# Altium schematic sheet TKT40339_Rhino_VB_20190430.SCH
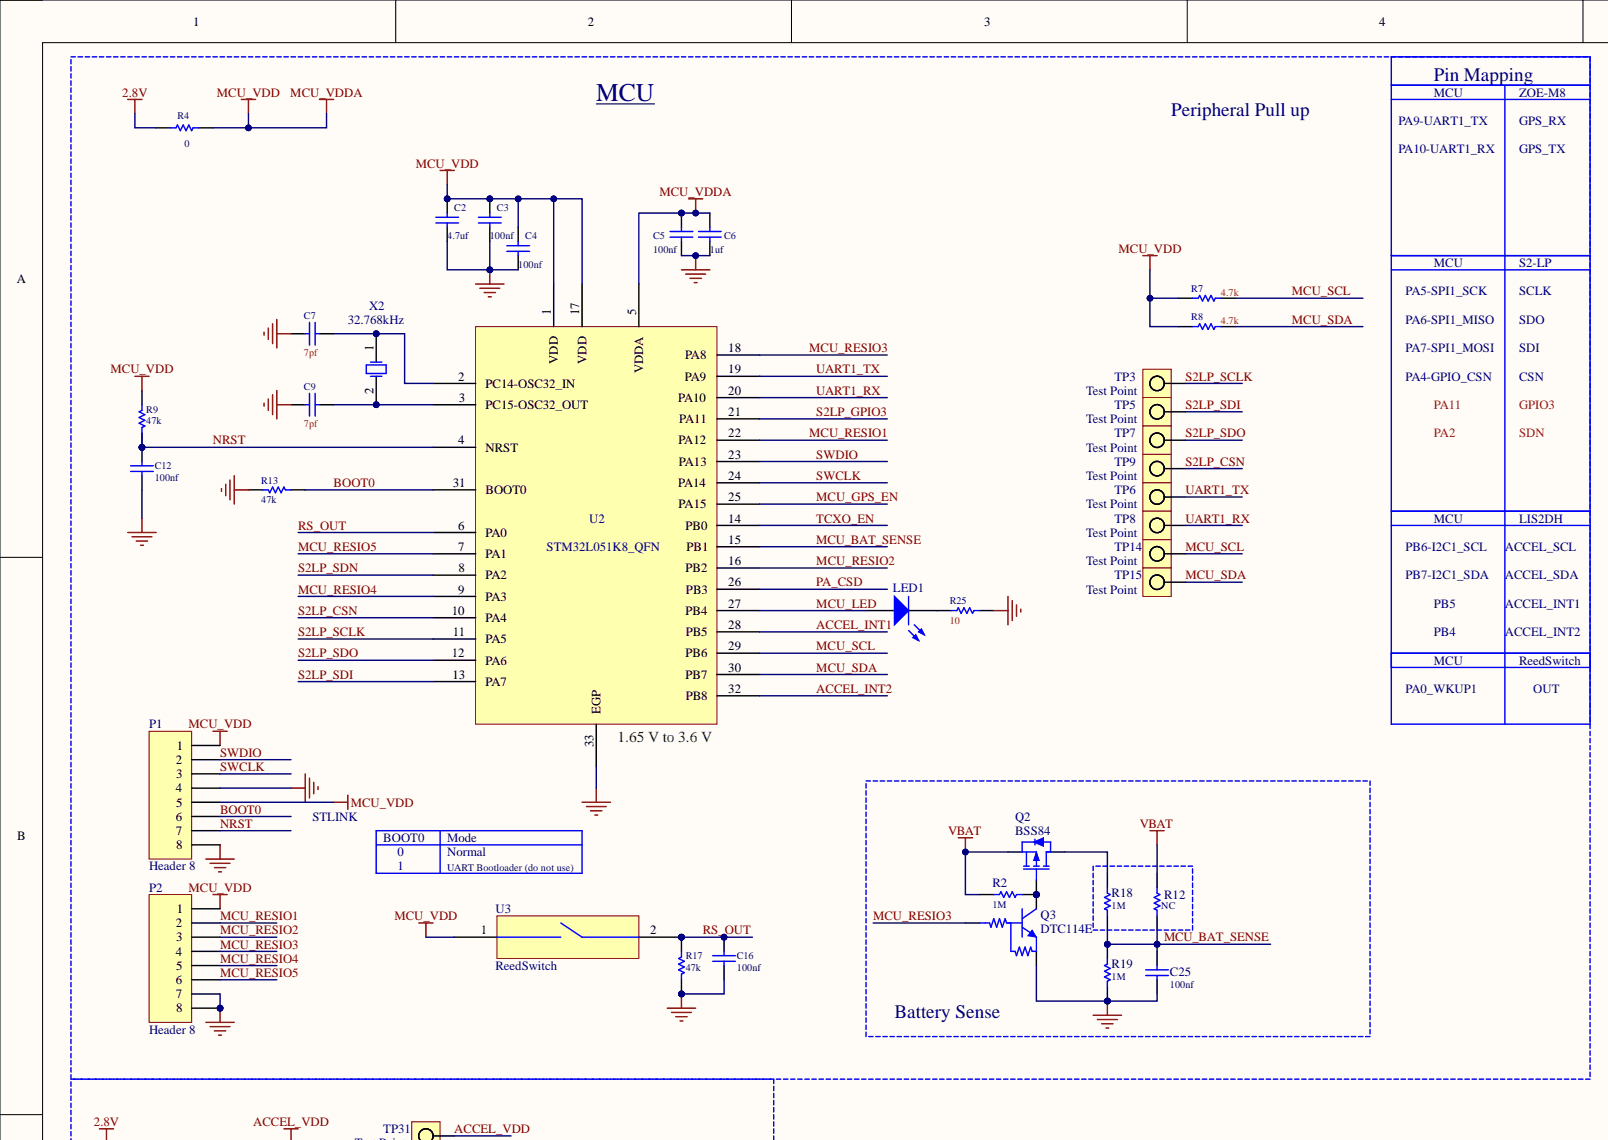
[diagram p0: TKT40339_Rhino_VB_20190430.SCH, top left]
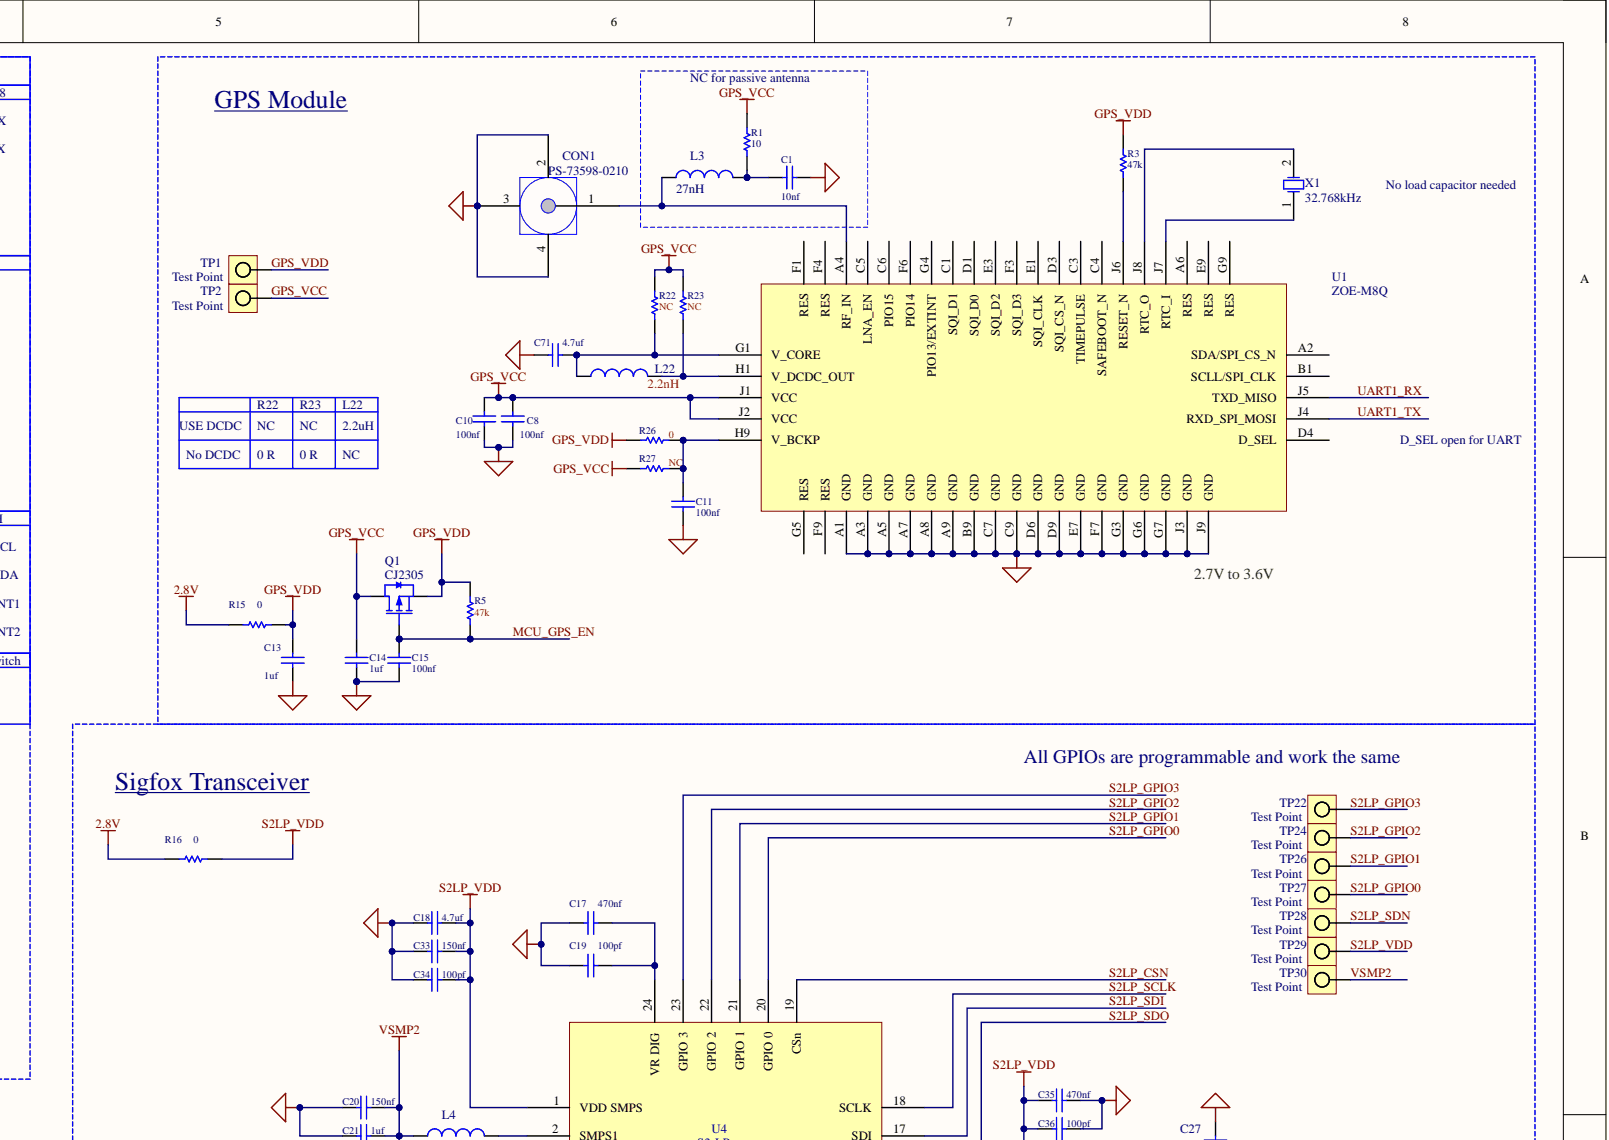
[diagram p1: TKT40339_Rhino_VB_20190430.SCH, top right]
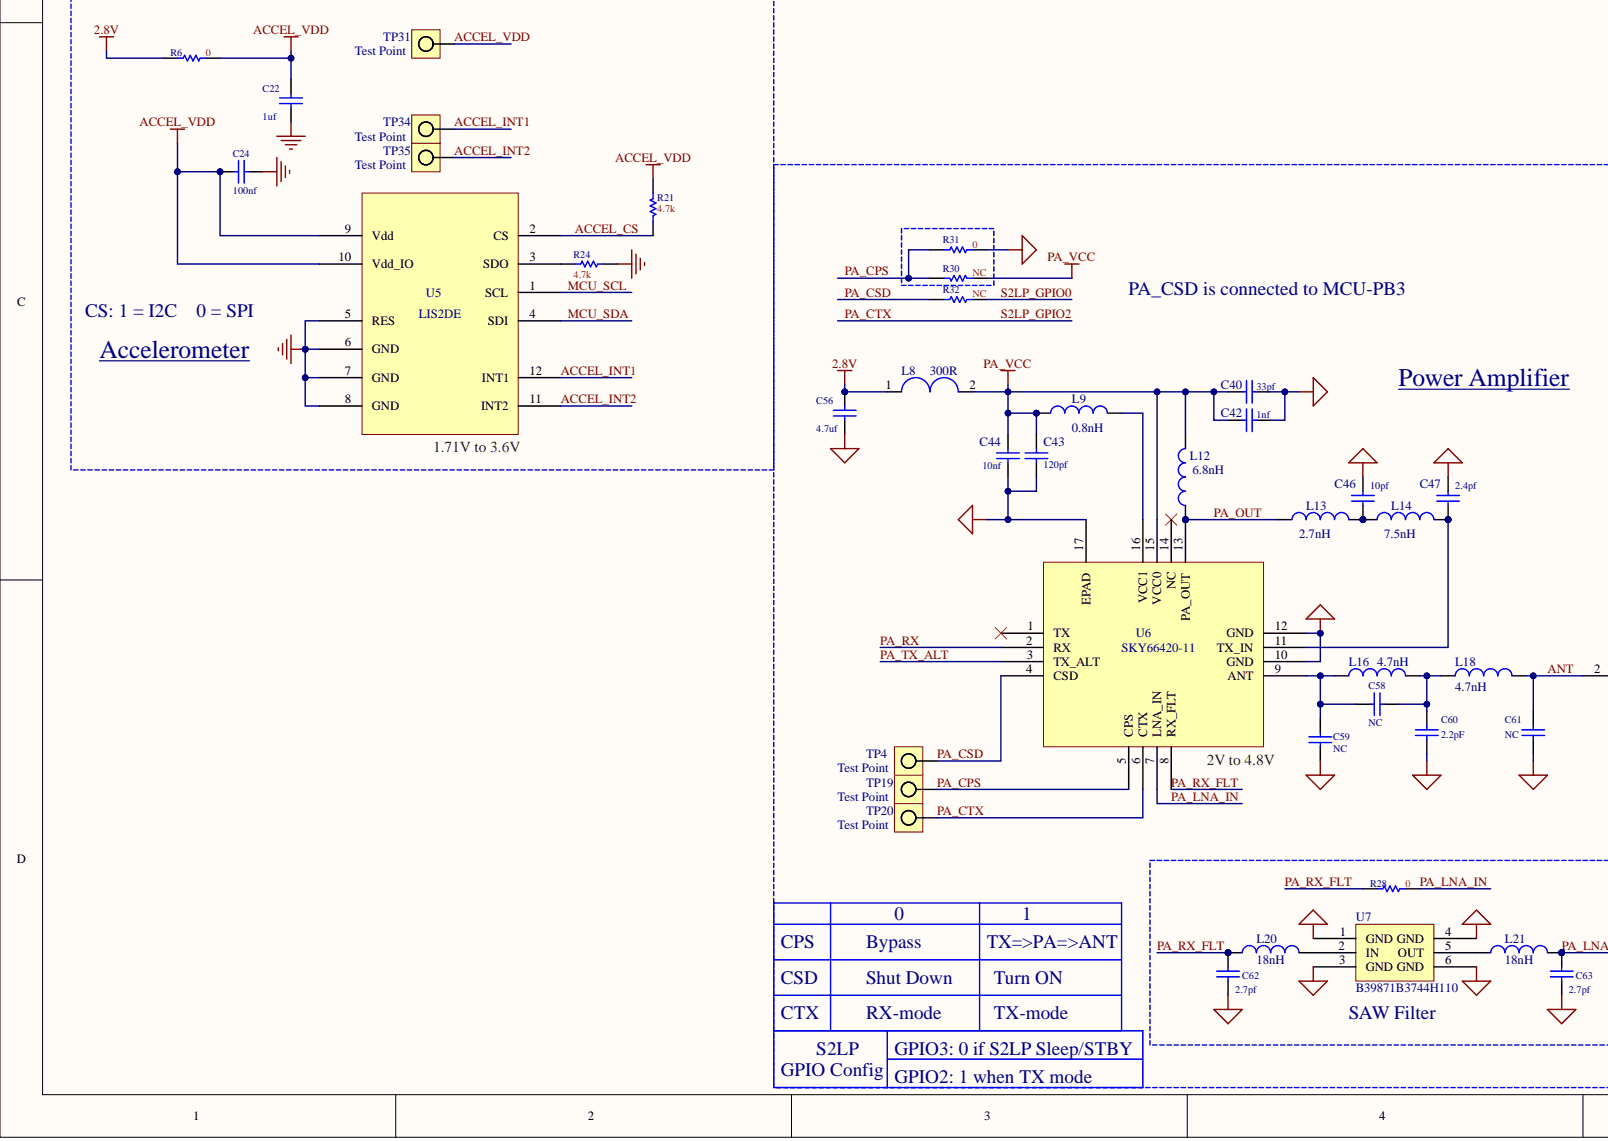
[diagram p2: TKT40339_Rhino_VB_20190430.SCH, bottom left]
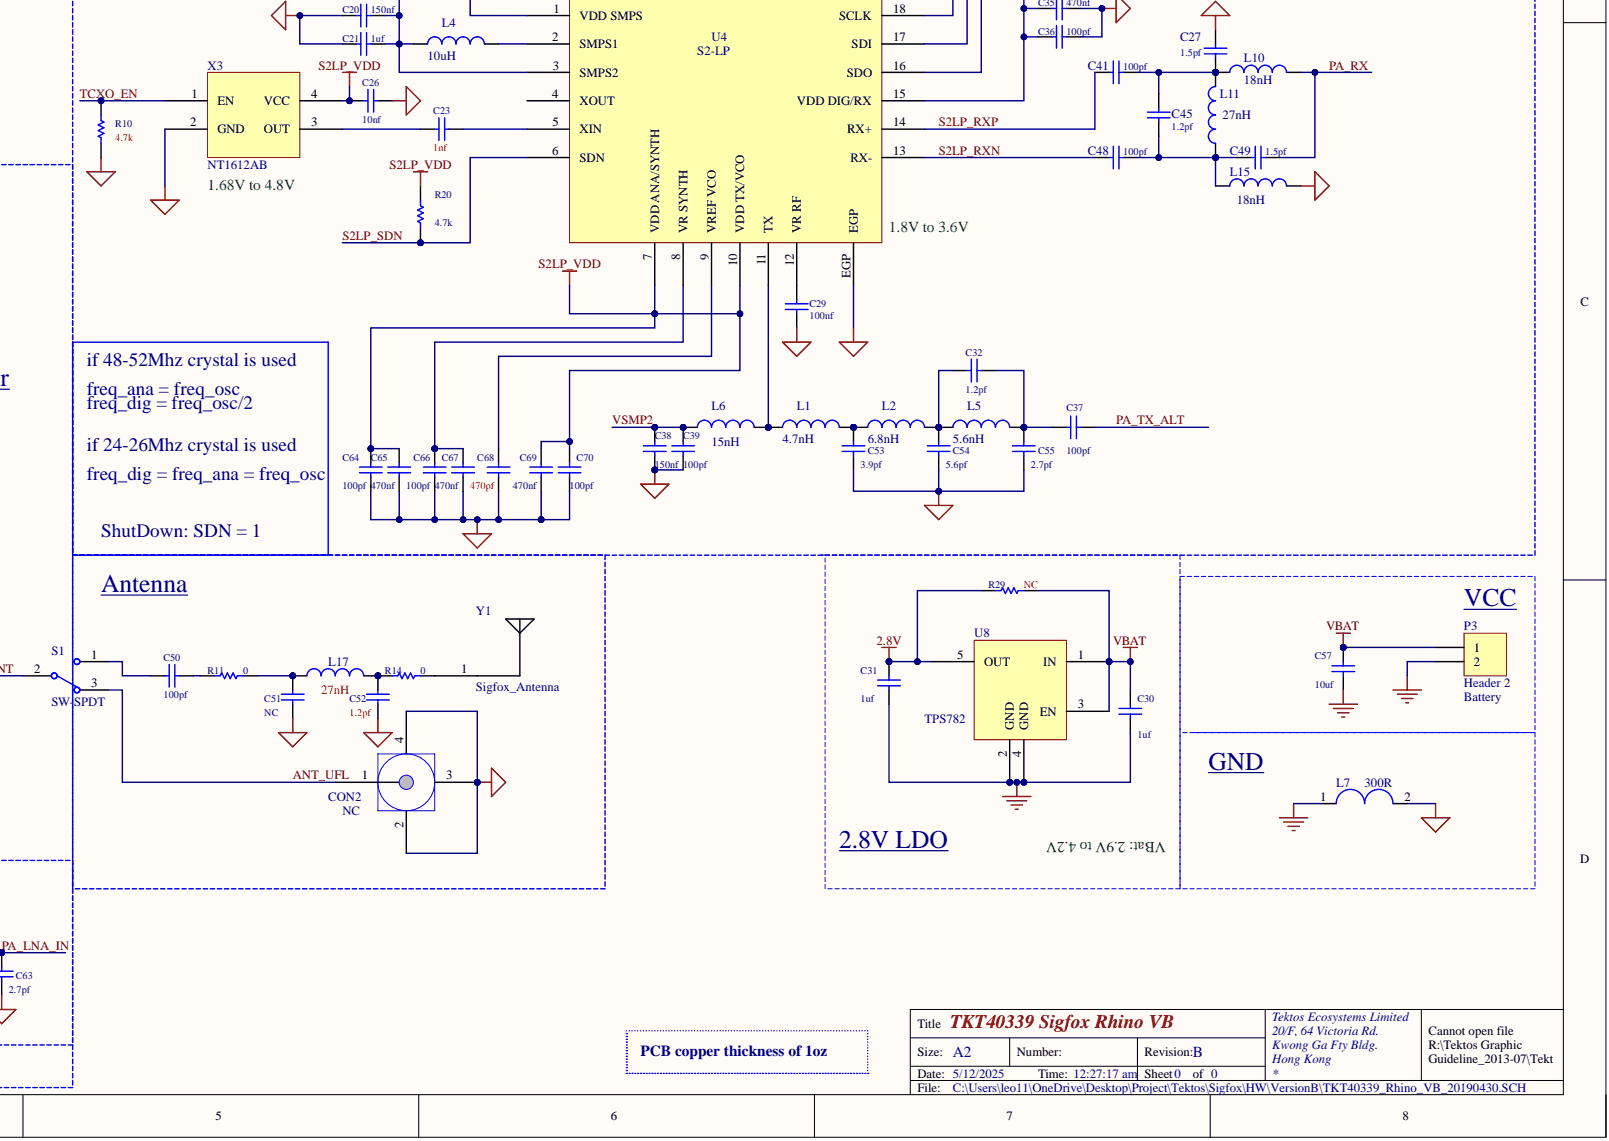
[diagram p3: TKT40339_Rhino_VB_20190430.SCH, bottom right]

## Parts
C1  10nf  [0402C_3D]
C2  4.7uf  [0402C_3D]
C3  100nf  [0402C_3D]
C4  100nf  [0402C_3D]
C5  100nf  [0402C_3D]
C6  1uf  [0402C_3D]
C7  7pf  [0402C_3D]
C8  100nf  [0402C_3D]
C9  7pf  [0402C_3D]
C10  100nf  [0402C_3D]
C11  100nf  [0402C_3D]
C12  100nf  [0402C_3D]
C13  1uf  [0402C_3D]
C14  1uf  [0402C_3D]
C15  100nf  [0402C_3D]
C16  100nf  [0402C_3D]
C17  470nf  [0402C_3D]
C18  4.7uf  [0402C_3D]
C19  100pf  [0402C_3D]
C20  150nf  [0402C_3D]
C21  1uf  [0402C_3D]
C22  1uf  [0402C_3D]
C23  1nf  [0402C_3D]
C24  100nf  [0402C_3D]
C25  100nf  [0402C_3D]
C26  10nf  [0402C_3D]
C27  1.5pf  [0402C_3D]
C29  100nf  [0402C_3D]
C30  1uf  [0402C_3D]
C31  1uf  [0402C_3D]
C32  1.2pf  [0402C_3D]
C33  150nf  [0402C_3D]
C34  100pf  [0402C_3D]
C35  470nf  [0402C_3D]
C36  100pf  [0402C_3D]
C37  100pf  [0402C_3D]
C38  150nf  [0402C_3D]
C39  100pf  [0402C_3D]
C40  33pf  [0402C_3D]
C41  100pf  [0402C_3D]
C42  1nf  [0402C_3D]
C43  120pf  [0402C_3D]
C44  10nf  [0402C_3D]
C45  1.2pf  [0402C_3D]
C46  10pf  [0402C_3D]
C47  2.4pf  [0402C_3D]
C48  100pf  [0402C_3D]
C49  1.5pf  [0402C_3D]
C50  100pf  [0402C_3D]
C51  NC  [0402C_3D]
C52  1.2pf  [0402C_3D]
C53  3.9pf  [0402C_3D]
C54  5.6pf  [0402C_3D]
C55  2.7pf  [0402C_3D]
C56  4.7uf  [0402C_3D]
C57  10uf  [0603C_3D]
C58  NC  [0402C_3D]
C59  NC  [0402C_3D]
C60  2.2pF  [0402C_3D]
C61  NC  [0402C_3D]
C62  2.7pf  [0402C_3D]
C63  2.7pf  [0402C_3D]
C64  100pf  [0402C_3D]
C65  470nf  [0402C_3D]
C66  100pf  [0402C_3D]
C67  470nf  [0402C_3D]
C68  470pf  [0402C_3D]
C69  470nf  [0402C_3D]
C70  100pf  [0402C_3D]
C71  4.7uf  [0402C_3D]
CON1  PS-73598-0210  [UFL_connector]
CON2  NC  [UFL_connector]
L1  4.7nH  [0402L_3D]
L2  6.8nH  [0402L_3D]
L3  27nH  [0402L_3D]
L4  10uH  [0603L_3D]
L5  5.6nH  [0402L_3D]
L6  15nH  [0402L_3D]
L7  300R  [0402L_3D]
L8  300R  [0402L_3D]
L9  0.8nH  [0402L_3D]
L10  18nH  [0402L_3D]
L11  27nH  [0402L_3D]
L12  6.8nH  [0402L_3D]
L13  2.7nH  [0402L_3D]
L14  7.5nH  [0402L_3D]
L15  18nH  [0402L_3D]
L16  4.7nH  [0402L_3D]
L17  27nH  [0402L_3D]
L18  4.7nH  [0402L_3D]
L20  18nH  [0402L_3D]
L21  18nH  [0402L_3D]
L22  2.2nH  [0603]
LED1  LED1  [APHHS1005QBC/D_LED0402_B_3D]
P1  Header 8  [HEADER_8P]
P2  Header 8  [HEADER_8P]
P3  Header 2  [HDR1X2]
Q1  CJ2305  [SOT23_3D (TO-236AB)]
Q2  BSS84  [SOT323_3D]
Q3  DTC114E  [SOT323_3D]
R1  10  [0402R-v2_3D]
R2  1M  [0402R-v2_3D]
R3  47k  [0402R-v2_3D]
R4  0  [0402R-v2_3D]
R5  47k  [0402R-v2_3D]
R6  0  [0402R-v2_3D]
R7  4.7k  [0402R-v2_3D]
R8  4.7k  [0402R-v2_3D]
R9  47k  [0402R-v2_3D]
R10  4.7k  [0402R-v2_3D]
R11  0  [0402R-v2_3D]
R12  NC  [0402R-v2_3D]
R13  47k  [0402R-v2_3D]
R14  0  [0402R-v2_3D]
R15  0  [0402R-v2_3D]
R16  0  [0402R-v2_3D]
R17  47k  [0402R-v2_3D]
R18  1M  [0402R-v2_3D]
R19  1M  [0402R-v2_3D]
R20  4.7k  [0402R-v2_3D]
R21  4.7k  [0402R-v2_3D]
R22  NC  [0402R-v2_3D]
R23  NC  [0402R-v2_3D]
R24  4.7k  [0402R-v2_3D]
R25  10  [0402R-v2_3D]
R26  0  [0402R-v2_3D]
R27  NC  [0402R-v2_3D]
R28  0  [0402R-v2_3D]
R29  NC  [0402R-v2_3D]
R30  NC  [0402R-v2_3D]
R31  0  [0402R-v2_3D]
R32  NC  [0402R-v2_3D]
S1  SW-SPDT  [0402R 3 pad L shape]
TP1  Test Point  [0.8mm Test pin]
TP2  Test Point  [0.8mm Test pin]
TP3  Test Point  [0.8mm Test pin]
TP4  Test Point  [0.8mm Test pin]
TP5  Test Point  [0.8mm Test pin]
TP6  Test Point  [0.8mm Test pin]
TP7  Test Point  [0.8mm Test pin]
TP8  Test Point  [0.8mm Test pin]
TP9  Test Point  [0.8mm Test pin]
TP14  Test Point  [0.8mm Test pin]
TP15  Test Point  [0.8mm Test pin]
TP19  Test Point  [0.8mm Test pin]
TP20  Test Point  [0.8mm Test pin]
TP22  Test Point  [0.8mm Test pin]
TP24  Test Point  [0.8mm Test pin]
TP26  Test Point  [0.8mm Test pin]
TP27  Test Point  [0.8mm Test pin]
TP28  Test Point  [0.8mm Test pin]
TP29  Test Point  [0.8mm Test pin]
TP30  Test Point  [0.8mm Test pin]
TP31  Test Point  [0.8mm Test pin]
TP34  Test Point  [0.8mm Test pin]
TP35  Test Point  [0.8mm Test pin]
U1  ZOE-M8Q  [s-lga]
U2  STM32L051K8_QFN  [UFQFPN32]
U3  ReedSwitch  [Reed switch 14027-Lifted]
U4  S2-LP  [QFN24L_4x4mm]
U5  LIS2DE  [LGA12-1]
U6  SKY66420-11  [SKY66420-11]
U7  B39871B3744H110  [3.0x3.0x1.0mm sawfilter]
U8  TPS782  [TSOT23-5]
X1  32.768kHz  [1.6x1.0x0.5mm_crystal]
X2  32.768kHz  [1.6x1.0x0.5mm_crystal]
X3  NT1612AB  [1.6x1.2x0.45mm tcxo]
Y1  Sigfox_Antenna  [Sigfox_Antenna]

## Nets
2.8V: C31.2 C56.2 L8.1 R4.2 R6.2 R15.2 R16.2 R29.2 U8.5(OUT)
AGND: C1.2 C8.2 C10.2 C11.1 C13.1 C14.1 C15.1 C17.2 C18.2 C19.2 C20.1 C21.1 C26.2 C27.2 C29.2 C33.1 C34.2 C35.1 C36.1 C38.1 C39.1 C40.2 C42.2 C43.2 C44.2 C46.2 C47.2 C51.1 C52.1 C53.1 C54.1 C55.1 C56.1 C59.1 C60.1 C61.1 C62.1 C63.1 C64.1 C65.1 C66.1 C67.1 C68.1 C69.1 C70.1 C71.1 CON1.2 CON1.3 CON1.4 CON2.2 CON2.3 CON2.4 L7.2 L15.2 R10.1 R31.1 U1.A1(GND) U1.A3(GND) U1.A5(GND) U1.A7(GND) U1.A8(GND) U1.A9(GND) U1.B9(GND) U1.C7(GND) U1.C9(GND) U1.D6(GND) U1.D9(GND) U1.E7(GND) U1.F7(GND) U1.G3(GND) U1.G6(GND) U1.G7(GND) U1.J3(GND) U1.J9(GND) U4.EGP U6.10(GND) U6.12(GND) U6.17(EPAD) U7.1(GND) U7.3(GND) U7.4(GND) U7.6(GND) X3.2(GND)
GND: C2.1 C3.1 C4.1 C5.1 C6.1 C7.2 C9.2 C12.1 C16.1 C22.1 C24.1 C25.2 C30.1 C31.1 C57.1 L7.1 P1.4 P1.8 P2.7 P2.8 P3.2 Q3.2 R13.1 R17.1 R19.1 R24.2 R25.1 U2.33(EGP) U5.5(RES) U5.6(GND) U5.7(GND) U5.8(GND) U8.2(GND) U8.4(GND)
MCU_VDD: C2.2 C3.2 C4.2 C5.2 C6.2 P1.1 P1.5 P2.1 R4.1 R7.2 R8.2 R9.2 U2.1(VDD) U2.5(VDDA) U2.17(VDD) U3.1
PA_VCC: C40.1 C42.1 C43.1 C44.1 L8.2 L9.1 L12.2 R30.1 U6.15(VCC0)
VBAT: C30.2 C57.2 P3.1 Q2.2 R2.2 R12.1 R29.1 U8.1(IN) U8.3(EN)
ACCEL_CS: R21.1 U5.2(CS)
ACCEL_INT1: TP34.1 U2.28(PB5) U5.12(INT1)
ACCEL_INT2: TP35.1 U2.32(PB8) U5.11(INT2)
ACCEL_VDD: C22.2 C24.2 R6.1 R21.2 TP31.1 U5.9(Vdd) U5.10(Vdd_IO)
ANT: C61.2 L18.2 S1.2
ANT_UFL: CON2.1 S1.3
BOOT0: P1.6 R13.2 U2.31(BOOT0)
GPS_VCC: C8.1 C10.1 C14.2 Q1.3 R1.1 R22.1 R23.1 R27.1 TP2.1 U1.J1(VCC) U1.J2(VCC)
GPS_VDD: C13.2 Q1.2 R3.1 R5.2 R15.1 R26.1 TP1.1
MCU_BAT_SENSE: C25.1 R12.2 R18.1 R19.2 U2.15(PB1)
MCU_GPS_EN: C15.2 Q1.1 R5.1 U2.25(PA15)
MCU_LED: LED1.1 U2.27(PB4)
MCU_RESIO1: P2.2 U2.22(PA12)
MCU_RESIO2: P2.3 U2.16(PB2)
MCU_RESIO3: P2.4 Q3.1 U2.18(PA8)
MCU_RESIO4: P2.5 U2.9(PA3)
MCU_RESIO5: P2.6 U2.7(PA1)
MCU_SCL: R7.1 TP14.1 U2.29(PB6) U5.1(SCL)
MCU_SDA: R8.1 TP15.1 U2.30(PB7) U5.4(SDI)
NRST: C12.2 P1.7 R9.1 U2.4(NRST)
PA_CPS: R30.2 R31.2 TP19.1 U6.5(CPS)
PA_CSD: R32.2 TP4.1 U2.26(PB3) U6.4(CSD)
PA_CTX: TP20.1 TP24.1 U4.22(GPIO_2) U6.6(CTX)
PA_LNA_IN: C63.2 L21.2 R28.1 U6.7(LNA_IN)
PA_OUT: L12.1 L13.1 U6.13(PA_OUT)
PA_RX: C49.1 L10.2 U6.2(RX)
PA_RX_FLT: C62.2 L20.1 R28.2 U6.8(RX_FLT)
PA_TX_ALT: C37.2 U6.3(TX_ALT)
RS_OUT: C16.2 R17.2 U2.6(PA0) U3.2
S2LP_CSN: TP9.1 U2.10(PA4) U4.19(CSn)
S2LP_GPIO0: R32.1 TP27.1 U4.20(GPIO_0)
S2LP_GPIO1: TP26.1 U4.21(GPIO_1)
S2LP_GPIO3: TP22.1 U2.21(PA11) U4.23(GPIO_3)
S2LP_RXN: C48.1 U4.13(RX-)
S2LP_RXP: C41.1 U4.14(RX+)
S2LP_SCLK: TP3.1 U2.11(PA5) U4.18(SCLK)
S2LP_SDI: TP5.1 U2.13(PA7) U4.17(SDI)
S2LP_SDN: R20.1 TP28.1 U2.8(PA2) U4.6(SDN)
S2LP_SDO: TP7.1 U2.12(PA6) U4.16(SDO)
S2LP_VDD: C18.1 C26.1 C33.2 C34.1 C35.2 C36.2 C64.2 C65.2 C69.2 C70.2 R16.1 R20.2 TP29.1 U4.1(VDD_SMPS) U4.7(VDD_ANA/SYNTH) U4.10(VDD_TX/VCO) U4.15(VDD_DIG/RX) X3.4(VCC)
SWCLK: P1.3 U2.24(PA14)
SWDIO: P1.2 U2.23(PA13)
TCXO_EN: R10.2 U2.14(PB0) X3.1(EN)
UART1_RX: TP8.1 U1.J5(TXD_MISO) U2.20(PA10)
UART1_TX: TP6.1 U1.J4(RXD_SPI_MOSI) U2.19(PA9)
VSMP2: C20.2 C21.2 C38.2 C39.2 L4.1 L6.1 TP30.1 U4.3(SMPS2)
NetC1_1: C1.1 L3.2 R1.2
NetC7_1: C7.1 U2.2(PC14-OSC32_IN) X2.1
NetC9_1: C9.1 U2.3(PC15-OSC32_OUT) X2.2
NetC11_2: C11.2 R26.2 R27.2 U1.H9(V_BCKP)
NetC17_1: C17.1 C19.1 U4.24(VR_DIG)
NetC23_1: C23.1 U4.5(XIN)
NetC23_2: C23.2 X3.3(OUT)
NetC27_1: C27.1 C41.2 C45.2 L10.1 L11.2
NetC29_1: C29.1 U4.12(VR_RF)
NetC32_1: C32.1 C37.1 C55.2 L5.2
NetC32_2: C32.2 C54.2 L2.2 L5.1
NetC45_1: C45.1 C48.2 C49.2 L11.1 L15.1
NetC46_1: C46.1 L13.2 L14.1
NetC47_1: C47.1 L14.2 U6.11(TX_IN)
NetC50_1: C50.1 S1.1
NetC50_2: C50.2 R11.2
NetC51_2: C51.2 L17.1 R11.1
NetC52_2: C52.2 L17.2 R14.2
NetC53_2: C53.2 L1.2 L2.1
NetC58_1: C58.1 C59.2 L16.1 U6.9(ANT)
NetC58_2: C58.2 C60.2 L16.2 L18.1
NetC66_2: C66.2 C67.2 U4.8(VR_SYNTH)
NetC68_2: C68.2 U4.9(VREF_VCO)
NetC71_2: C71.2 L22.1 R22.2 U1.G1(V_CORE)
NetCON1_1: CON1.1 L3.1 U1.A4(RF_IN)
NetL1_1: L1.1 L6.2 U4.11(TX)
NetL4_2: L4.2 U4.2(SMPS1)
NetL9_2: L9.2 U6.16(VCC1)
NetL20_2: L20.2 U7.2(IN)
NetL21_1: L21.1 U7.5(OUT)
NetL22_2: L22.2 R23.2 U1.H1(V_DCDC_OUT)
NetLED1_2: LED1.2 R25.2
NetQ2_1: Q2.1 Q3.3 R2.1
NetQ2_3: Q2.3 R18.2
NetR3_2: R3.2 U1.J6(RESET_N)
NetR14_1: R14.1 Y1.1
NetR24_1: R24.1 U5.3(SDO)
NetU1_J7: U1.J7(RTC_I) X1.1
NetU1_J8: U1.J8(RTC_O) X1.2

Not connected: U1 A2(SDA/SPI_CS_N) A6(RES) B1(SCLL/SPI_CLK) C1(SQI_D1) C3(TIMEPULSE) C4(SAFEBOOT_N) C5(LNA_EN) C6(PIO15) D1(SQI_D0) D3(SQI_CS_N) D4(D_SEL) E1(SQI_CLK) E3(SQI_D2) E9(RES) F1(RES) F3(SQI_D3) F4(RES) F6(PIO14) F9(RES) G4(PIO13/EXTINT) G5(RES) G9(RES); U4 4(XOUT); U6 1(TX) 14(NC)

## Library data of the parts
C1 C26 C44 = Cap: CAP, 0402, 10V, 10nf; Manufacturer: Generic Components; Manufacturer Part Number: 0402ZC103MAT2A; Class I: Passive; Class II: Capacitor; Component Kind: Standard; PN: 0402ZC103MAT2A; Published: 8-Jun-2000; Revision: July-2002: Re-released for DXP Platform.; Publisher: Altium Limited
C2 C18 C56 = Cap: CAP, 0402, 10V, 4.7uf; Manufacturer: Generic Components; Manufacturer Part Number: ZRB15XR61A475ME01D; Class I: Passive; Class II: Capacitor; Component Kind: Standard; PN: ZRB15XR61A475ME01D; Published: 8-Jun-2000; Revision: July-2002: Re-released for DXP Platform.; Publisher: Altium Limited
C3-C5 C8 C10-C12 C15 C16 C24 C25 C29 C71 = Cap: CAP, 0402, 10V, 100nf; Manufacturer: Generic Components; Manufacturer Part Number: 0402ZD104KAT4A; Class I: Passive; Class II: Capacitor; Component Kind: Standard; PN: 0402ZD104KAT4A; Published: 8-Jun-2000; Revision: July-2002: Re-released for DXP Platform.; Publisher: Altium Limited
C6 C13 C14 C21 C22 C30 C31 = Cap: CAP, 0402, 10V, 1uf; Manufacturer: Generic Components; Manufacturer Part Number: CC0402JRX5R6BB104; Class I: Passive; Class II: Capacitor; Component Kind: Standard; PN: CC0402JRX5R6BB104; Published: 8-Jun-2000; Revision: July-2002: Re-released for DXP Platform.; Publisher: Altium Limited
C7 C9 = Cap: CAP, 0402, 10V, 20pf; Manufacturer: Generic Components; Manufacturer Part Number: C0402C200K8GACTU; Class I: Passive; Class II: Capacitor; Component Kind: Standard; PN: C0402C200K8GACTU; Published: 8-Jun-2000; Revision: July-2002: Re-released for DXP Platform.; Publisher: Altium Limited
C17 C35 C65 C67 C69 = Cap: CAP, 0402, 10V, 470nf; Manufacturer: Generic Components; Manufacturer Part Number: LWK105BJ474MP-F; Class I: Passive; Class II: Capacitor; Component Kind: Standard; PN: LWK105BJ474MP-F; Published: 8-Jun-2000; Revision: July-2002: Re-released for DXP Platform.; Publisher: Altium Limited
C19 C34 C36 C37 C39 C41 C48 C50 C64 C66 C70 = Cap: CAP, 0402, 10V, 100pf; Manufacturer: Generic Components; Manufacturer Part Number: 885012205001; Class I: Passive; Class II: Capacitor; Component Kind: Standard; PN: 885012205001; Published: 8-Jun-2000; Revision: July-2002: Re-released for DXP Platform.; Publisher: Altium Limited
C20 C33 C38 = Cap: CAP, 0402, 10V, 150nf; Manufacturer: Generic Components; Manufacturer Part Number: C1005X7R1A154M050BB; Class I: Passive; Class II: Capacitor; Component Kind: Standard; PN: C1005X7R1A154M050BB; Published: 8-Jun-2000; Revision: July-2002: Re-released for DXP Platform.; Publisher: Altium Limited
C23 C42 = Cap: CAP, 0402, 10V, 1nf; Manufacturer: Generic Components; Manufacturer Part Number: C0402C102K1GECAUTO; Class I: Passive; Class II: Capacitor; Component Kind: Standard; PN: C0402C102K1GECAUTO; Published: 8-Jun-2000; Revision: July-2002: Re-released for DXP Platform.; Publisher: Altium Limited
C27 C49 = Cap: CAP, 0402, 10V, 1.5pf; Manufacturer: Generic Components; Manufacturer Part Number: GJM1555C1H1R5BB01D; Class I: Passive; Class II: Capacitor; Component Kind: Standard; PN: GJM1555C1H1R5BB01D; Published: 8-Jun-2000; Revision: July-2002: Re-released for DXP Platform.; Publisher: Altium Limited
C32 C45 = Cap: CAP, 0402, 10V, 1.2pf; Manufacturer: Generic Components; Manufacturer Part Number: GJM1555C1H1R2BB01D; Class I: Passive; Class II: Capacitor; Component Kind: Standard; PN: GJM1555C1H1R2BB01D; Published: 8-Jun-2000; Revision: July-2002: Re-released for DXP Platform.; Publisher: Altium Limited
C40 = Cap: CAP, 0402, 10V, 33pf; Manufacturer: Generic Components; Manufacturer Part Number: 885012005010; Class I: Passive; Class II: Capacitor; Component Kind: Standard; PN: 885012005010; Published: 8-Jun-2000; Revision: July-2002: Re-released for DXP Platform.; Publisher: Altium Limited
C43 = Cap: CAP, 0402, 10V, 120pf; Manufacturer: Generic Components; Manufacturer Part Number: C0402C121J5GACTU; Class I: Passive; Class II: Capacitor; Component Kind: Standard; PN: C0402C121J5GACTU; Published: 8-Jun-2000; Revision: July-2002: Re-released for DXP Platform.; Publisher: Altium Limited
C46 = Cap: CAP, 0402, 10V, 10pf; Manufacturer: Generic Components; Manufacturer Part Number: 885012005007; Class I: Passive; Class II: Capacitor; Component Kind: Standard; PN: 885012005007; Published: 8-Jun-2000; Revision: July-2002: Re-released for DXP Platform.; Publisher: Altium Limited
C47 = Cap: CAP, 0402, 10V, 2.4pf; Manufacturer: Generic Components; Manufacturer Part Number: GJM1555C1H2R4WB01D; Class I: Passive; Class II: Capacitor; Component Kind: Standard; PN: GJM1555C1H2R4WB01D; Published: 8-Jun-2000; Revision: July-2002: Re-released for DXP Platform.; Publisher: Altium Limited
C51 = Cap: CAP, 0402, TBD; Manufacturer: Generic Components; Class I: Passive; Class II: Capacitor; Component Kind: Standard; Published: 8-Jun-2000; Revision: July-2002: Re-released for DXP Platform.; Publisher: Altium Limited
C52 = Cap: CAP, 0402, TBD; Manufacturer: Generic Components; Manufacturer Part Number: GJM1555C1H1R2WB01D; Class I: Passive; Class II: Capacitor; Component Kind: Standard; PN: GJM1555C1H1R2WB01D; Published: 8-Jun-2000; Revision: July-2002: Re-released for DXP Platform.; Publisher: Altium Limited
C53 = Cap: CAP, 0402, 10V, 3.9pf; Manufacturer: Generic Components; Manufacturer Part Number: UVK105CG3R9JW-F; Class I: Passive; Class II: Capacitor; Component Kind: Standard; PN: UVK105CG3R9JW-F; Published: 8-Jun-2000; Revision: July-2002: Re-released for DXP Platform.; Publisher: Altium Limited
C54 = Cap: CAP, 0402, 10V, 5.6pf; Manufacturer: Generic Components; Manufacturer Part Number: VJ0402A5R6CXQPW1BC; Class I: Passive; Class II: Capacitor; Component Kind: Standard; PN: VJ0402A5R6CXQPW1BC; Published: 8-Jun-2000; Revision: July-2002: Re-released for DXP Platform.; Publisher: Altium Limited
C55 C62 C63 = Cap: CAP, 0402, 10V, 2.7pf; Manufacturer: Generic Components; Manufacturer Part Number: GRM0225C1E2R7CA03L; Class I: Passive; Class II: Capacitor; Component Kind: Standard; PN: GRM0225C1E2R7CA03L; Published: 8-Jun-2000; Revision: July-2002: Re-released for DXP Platform.; Publisher: Altium Limited
C57 = Cap: CAP, 0603, 16V, 10uf; Manufacturer: Generic Components; Manufacturer Part Number: C0603C103M4RACTU; Class I: Passive; Class II: Capacitor; Component Kind: Standard; PN: C0603C103M4RACTU; Published: 8-Jun-2000; Revision: July-2002: Re-released for DXP Platform.; Publisher: Altium Limited
C58 C59 C61 = Cap: CAP, 0402, NC; Manufacturer: Generic Components; Class I: Passive; Class II: Capacitor; Component Kind: Standard; Published: 8-Jun-2000; Revision: July-2002: Re-released for DXP Platform.; Publisher: Altium Limited
C60 = Cap: CAP, 0402, 10V, 2.2pf; Manufacturer: Generic Components; Manufacturer Part Number: 885012005003; Class I: Passive; Class II: Capacitor; Component Kind: Standard; PN: 885012005003; Published: 8-Jun-2000; Revision: July-2002: Re-released for DXP Platform.; Publisher: Altium Limited
C68 = Cap: CAP, 0402, 10V, 470pf; Manufacturer: Generic Components; Manufacturer Part Number: 885012005017; Class I: Passive; Class II: Capacitor; Component Kind: Standard; PN: 885012005017; Published: 8-Jun-2000; Revision: July-2002: Re-released for DXP Platform.; Publisher: Altium Limited
CON1 = UFL_RF_CONNECTOR: UFL connector; Manufacturer Part Number: CONUFL001-SMD; Component Kind: Standard; PN: CONUFL001-SMD; Library Name: TektosLib.SchLib
CON2 = UFL_RF_CONNECTOR: UFL connector; Component Kind: Standard; Library Name: TektosLib.SchLib
L1 L16 L18 = Inductor: Inductor, 4.7nH, 0402; Manufacturer Part Number: MLG1005S4N7CT000; Component Kind: Standard; Library Name: Miscellaneous Devices.IntLib; PN: MLG1005S4N7CT000; Published: 8-Jun-2000; Signal Integrity: Inductor; Simulation: INDUCTOR; Publisher: Altium Limited
L2 L12 = Inductor: Inductor, 6.8nH, 0402; Manufacturer Part Number: LQG15HZ6N8J02D; Component Kind: Standard; Library Name: Miscellaneous Devices.IntLib; PN: LQG15HZ6N8J02D; Published: 8-Jun-2000; Signal Integrity: Inductor; Simulation: INDUCTOR; Publisher: Altium Limited
L3 L11 = Inductor: Inductor, 27nH, 0402; Manufacturer Part Number: LQW15AN27NG80D; Component Kind: Standard; Library Name: Miscellaneous Devices.IntLib; PN: LQW15AN27NG80D; Published: 8-Jun-2000; Signal Integrity: Inductor; Simulation: INDUCTOR; Publisher: Altium Limited
L4 = Inductor: Inductor, 10uH, 0603; Manufacturer Part Number: LQM18FN100M00D; Component Kind: Standard; Library Name: Miscellaneous Devices.IntLib; PN: LQM18FN100M00D; Published: 8-Jun-2000; Signal Integrity: Inductor; Simulation: INDUCTOR; Publisher: Altium Limited
L5 = Inductor: Inductor, 5.6nH, 0402; Manufacturer Part Number: LQG15WZ5N6S02D; Component Kind: Standard; Library Name: Miscellaneous Devices.IntLib; PN: LQG15WZ5N6S02D; Published: 8-Jun-2000; Signal Integrity: Inductor; Simulation: INDUCTOR; Publisher: Altium Limited
L6 = Inductor: Inductor, 15nH, 0402; Manufacturer Part Number: LQG15HZ15NJ02D; Component Kind: Standard; Library Name: Miscellaneous Devices.IntLib; PN: LQG15HZ15NJ02D; Published: 8-Jun-2000; Signal Integrity: Inductor; Simulation: INDUCTOR; Publisher: Altium Limited
L7 L8 = FERRITE_BEAD: Ferrite bead, 300R, 200mA; Manufacturer Part Number: ILBB0402ER301V; Component Kind: Standard; PN: ILBB0402ER301V; Library Name: TektosLib.SchLib
L9 = Inductor: Inductor, 0.8nH, 0402; Manufacturer Part Number: MLG1005S0N8C; Component Kind: Standard; Library Name: Miscellaneous Devices.IntLib; PN: MLG1005S0N8C; Published: 8-Jun-2000; Signal Integrity: Inductor; Simulation: INDUCTOR; Publisher: Altium Limited
L10 L15 L20 L21 = Inductor: Inductor, 18nH, 0402; Manufacturer Part Number: LQG15HN18NH02D; Component Kind: Standard; Library Name: Miscellaneous Devices.IntLib; PN: LQG15HN18NH02D; Published: 8-Jun-2000; Signal Integrity: Inductor; Simulation: INDUCTOR; Publisher: Altium Limited
L13 = Inductor: Inductor, 2.7nH, 0402; Manufacturer Part Number: GCM1555C1H2R7BA16D; Component Kind: Standard; Library Name: Miscellaneous Devices.IntLib; PN: GCM1555C1H2R7BA16D; Published: 8-Jun-2000; Signal Integrity: Inductor; Simulation: INDUCTOR; Publisher: Altium Limited
L14 = Inductor: Inductor, 7.5nH, 0402; Manufacturer Part Number: LQG15HS7N5H02D; Component Kind: Standard; Library Name: Miscellaneous Devices.IntLib; PN: LQG15HS7N5H02D; Published: 8-Jun-2000; Signal Integrity: Inductor; Simulation: INDUCTOR; Publisher: Altium Limited
L17 = Inductor: Inductor, TBD, 0402; Manufacturer Part Number: LQW15AN27NG80D; Component Kind: Standard; Library Name: Miscellaneous Devices.IntLib; PN: LQW15AN27NG80D; Published: 8-Jun-2000; Signal Integrity: Inductor; Simulation: INDUCTOR; Publisher: Altium Limited
L22 = Inductor: Inductor, 2.2nH, 0402; Manufacturer Part Number: LQM2MPN2R2NG0; Component Kind: Standard; Library Name: Miscellaneous Devices.IntLib; PN: LQM2MPN2R2NG0; Published: 8-Jun-2000; Signal Integrity: Inductor; Simulation: INDUCTOR; Publisher: Altium Limited
LED1 = LED1: LED Green 0402; Manufacturer Part Number: APHHS1005CGCK; Component Kind: Standard; PN: APHHS1005CGCK; Library Name: TektosLib.SchLib
P1 P2 = Header 8: Header, 8-Pin; Component Kind: Standard; Library Name: Miscellaneous Connectors.IntLib; Signal Integrity: Connector; Publisher: Altium Limited
P3 = Header 2: Header, 2-Pin; Component Kind: Standard; Library Name: Miscellaneous Connectors.IntLib; Signal Integrity: Connector; Publisher: Altium Limited
Q1 = CJ2305: P-CHANNEL 8-VDS MOSFET; Component Kind: Standard; Manufacturer Part Number: CJ2305; PN: CJ2305; Library Name: TektosLib.SchLib
Q2 = BSS84: P-CHANNEL ENHANCEMENT MODE MOSFET; Manufacturer Part Number: BSS84WQ-7-F; Component Kind: Standard; PN: BSS84WQ-7-F; Library Name: TektosLib.SchLib
Q3 = DTC114E: R1=10K, R2=10K, NPN Transistors with Monolithic Bias  Resistor NetworkNPN Transistors with Monolithic Bias  Resistor Network; Manufacturer Part Number: ADTC114EUAQ-7; Component Kind: Standard; PN: ADTC114EUAQ-7; Library Name: TektosLib_Other.SchLib
R1 = Res1: Resistor, 0402, 10ohm; Manufacturer: Generic Components; Manufacturer Part Number: AC0402FR-0710RL; Class I: Passive; Class II: Resistor; Component Kind: Standard; PN: AC0402FR-0710RL; Published: 8-Jun-2000; Revision: July-2002: Re-released for DXP Platform.; Publisher: Altium Limited
R2 R18 R19 = Res1: Resistor, 0402, 1M ohm; Manufacturer: Generic Components; Manufacturer Part Number: RC0402FR-101ML; Class I: Passive; Class II: Resistor; Component Kind: Standard; PN: RC0402FR-101ML; Published: 8-Jun-2000; Revision: July-2002: Re-released for DXP Platform.; Publisher: Altium Limited
R3 R5 R9 R13 R17 = Res1: Resistor, 0402, 47k ohm; Manufacturer: Generic Components; Manufacturer Part Number: CRCW040247K0FKEDC; Class I: Passive; Class II: Resistor; Component Kind: Standard; PN: CRCW040247K0FKEDC; Published: 8-Jun-2000; Revision: July-2002: Re-released for DXP Platform.; Publisher: Altium Limited
R4 R6 R11 R14-R16 R26 R28 = Res1: Resistor, 0402, 0ohm; Manufacturer: Generic Components; Manufacturer Part Number: CRCW04020000Z0EDC; Class I: Passive; Class II: Resistor; Component Kind: Standard; PN: CRCW04020000Z0EDC; Published: 8-Jun-2000; Revision: July-2002: Re-released for DXP Platform.; Publisher: Altium Limited
R7 R8 R10 R20 R21 R24 = Res1: Resistor, 0402, 47k ohm; Manufacturer: Generic Components; Manufacturer Part Number: RT0402FRE074K7L; Class I: Passive; Class II: Resistor; Component Kind: Standard; PN: RT0402FRE074K7L; Published: 8-Jun-2000; Revision: July-2002: Re-released for DXP Platform.; Publisher: Altium Limited
R12 = Res1: Resistor, 0402, NC; Manufacturer: Generic Components; Class I: Passive; Class II: Resistor; Component Kind: Standard; Published: 8-Jun-2000; Revision: July-2002: Re-released for DXP Platform.; Publisher: Altium Limited
R22 R27 R29 R30 = Res1: NC/ Resistor, 0402, 0ohm; Manufacturer: Generic Components; Class I: Passive; Class II: Resistor; Component Kind: Standard; Published: 8-Jun-2000; Revision: July-2002: Re-released for DXP Platform.; Publisher: Altium Limited
R23 = Res1: NC/ Resistor, 0402, 0ohm; Manufacturer: Generic Components; Manufacturer Part Number: CRCW04020000Z0EDC; Class I: Passive; Class II: Resistor; Component Kind: Standard; PN: CRCW04020000Z0EDC; Published: 8-Jun-2000; Revision: July-2002: Re-released for DXP Platform.; Publisher: Altium Limited
R25 = Res1: Resistor, 0402, 10 ohm; Manufacturer: Generic Components; Manufacturer Part Number: AC0402FR-0710RL; Class I: Passive; Class II: Resistor; Component Kind: Standard; PN: AC0402FR-0710RL; Published: 8-Jun-2000; Revision: July-2002: Re-released for DXP Platform.; Publisher: Altium Limited
R31 = Res1: Resistor, 0402, 100 ohm; Manufacturer: Generic Components; Manufacturer Part Number: CRCW04020000Z0EDC; Class I: Passive; Class II: Resistor; Component Kind: Standard; PN: CRCW04020000Z0EDC; Published: 8-Jun-2000; Revision: July-2002: Re-released for DXP Platform.; Publisher: Altium Limited
R32 = Res1: NC, Resistor, 0402, 100 ohm; Manufacturer: Generic Components; Class I: Passive; Class II: Resistor; Component Kind: Standard; Published: 8-Jun-2000; Revision: July-2002: Re-released for DXP Platform.; Publisher: Altium Limited
S1 = SW-SPDT: SPDT Subminiature Toggle Switch, Right Angle Mounting, Vertical Actuation; Manufacturer Part Number: CRCW04020000Z0EDC; Component Kind: Standard; Library Name: Miscellaneous Devices.IntLib; PN: CRCW04020000Z0EDC; Published: 24-Mar-1999; Publisher: Altium Limited
TP1-TP9 TP14 TP15 TP19 TP20 TP22 TP24 TP26-TP31 TP34 TP35 = TEST_POINT: Component Kind: Standard; Library Name: TektosLib.SchLib
U1 = ZOE-M8Q: ZOE-M8Q, Ublox, GPS SIP; Component Kind: Standard; Manufacturer Part Number: ZOE-M8Q; PN: ZOE-M8Q; Library Name: TektosLib.SchLib
U2 = STM32L051K8_QFN: ultra-low-power 32-bit MCU Arm®-based Cortex®-M0+,  up to 64 KB Flash, 8 KB SRAM, 2 KB EEPROM, ADC; Manufacturer Part Number: STM32L051K8U6; Component Kind: Standard; PN: STM32L051K8U6; Library Name: TektosLib_IC.SchLib
U3 = ReedSwitch: Manufacturer Part Number: GR560152001; Component Kind: Standard; Description: ReedSwitch; PN: GR560152001; Library Name: TektosLib.SchLib
U4 = S2-LP: Ultra-low power, high performance, sub-1GHz transceiver; Manufacturer Part Number: S2-LPQTR; Component Kind: Standard; PN: S2-LPQTR; Library Name: TektosLib.SchLib
U5 = LIS2DE: ultra low-power high performance 3-axes “femto” accelerometer; Manufacturer Part Number: LIS2DE12TR; Component Kind: Standard; PN: LIS2DE12TR; Library Name: TektosLibFrankie.SCHLIB
U6 = SKY66420-11: SKY66420-11: 860 to 930 MHz RF Front-End Module; Component Kind: Standard; Manufacturer Part Number: SKY66420-11; PN: SKY66420-11; Library Name: TektosLib.SchLib
U7 = B39871B3744H110: TDK, SMD 868.3 MHz SAW FILTER; Component Kind: Standard; Manufacturer Part Number: B39871B3744H110; PN: B39871B3744H110; Library Name: TektosLib_Other.SchLib
U8 = TPS782: TPS782, TI, LDO, Iq = 500nA, Iout = 150mA, Vout = 2.8V, Vdropout = 130mV; Manufacturer Part Number: TPS78228DDCT; Component Kind: Standard; PN: TPS78228DDCT; Library Name: TektosLib_IC.SchLib
X1 = XTAL: 32.768khz, +/-20ppm, 4pF; Manufacturer Part Number: ECS-.327-7-16-C-TR; Component Kind: Standard; Library Name: Miscellaneous Devices.IntLib; PN: ECS-.327-7-16-C-TR; Published: 8-Jun-2000; Simulation: XTAL; Publisher: Altium Limited
X2 = XTAL: 32.768khz, +/-20ppm, 4pF; Manufacturer: ABRACON; Manufacturer Part Number: ECS-.327-7-16-C-TR; Component Kind: Standard; Library Name: Miscellaneous Devices.IntLib; PN: ECS-.327-7-16-C-TR; Published: 8-Jun-2000; Simulation: XTAL; Publisher: Altium Limited
X3 = NT1612AB: Temperature Compensated Crystal Oscillator(TCXO) with E/D function for high-precision GPS,  TCXO, 10pF; Manufacturer Part Number: NT1612AB-50MHZ-END5348A; Component Kind: Standard; PN: NT1612AB-50MHZ-END5348A; Library Name: TektosLib.SchLib
Y1 = Sigfox_Antenna: Sigfox Antenna; Component Kind: Standard; Library Name: TektosLib_Other.SchLib
